# Altium schematic sheet 07_HighSpeed_Peripherals.SchDoc
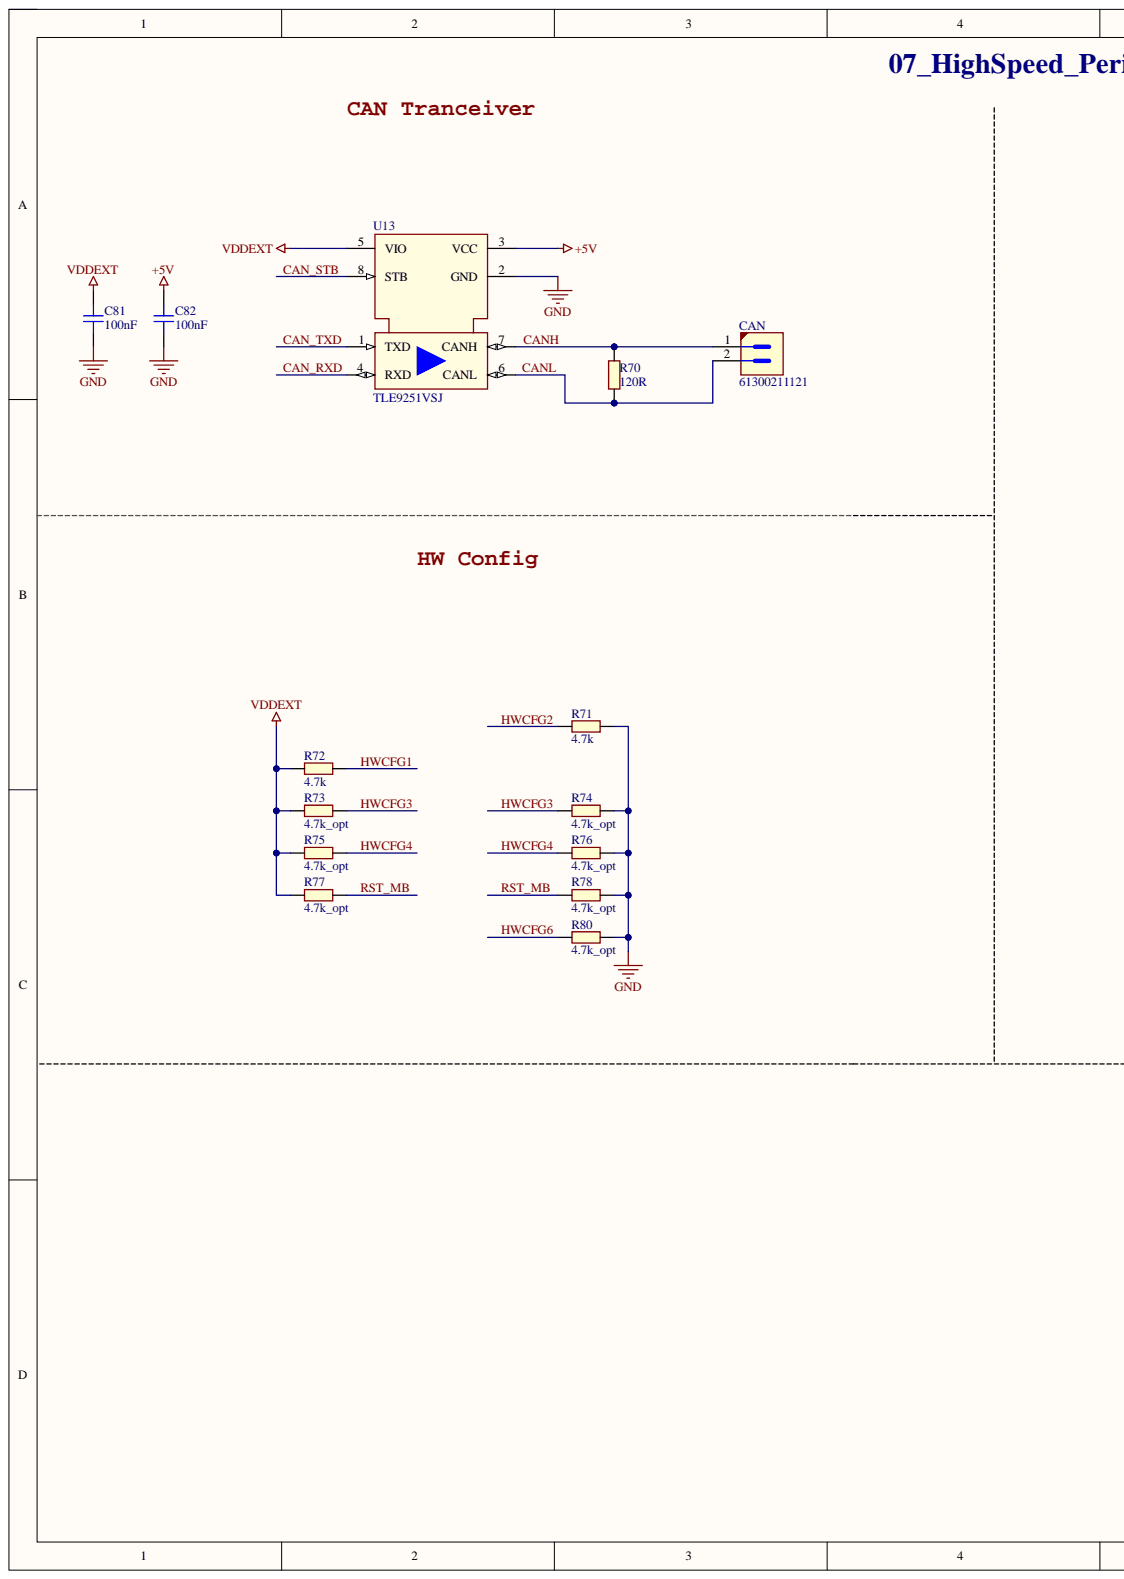
[diagram p0: 07_HighSpeed_Peripherals.SchDoc, left]
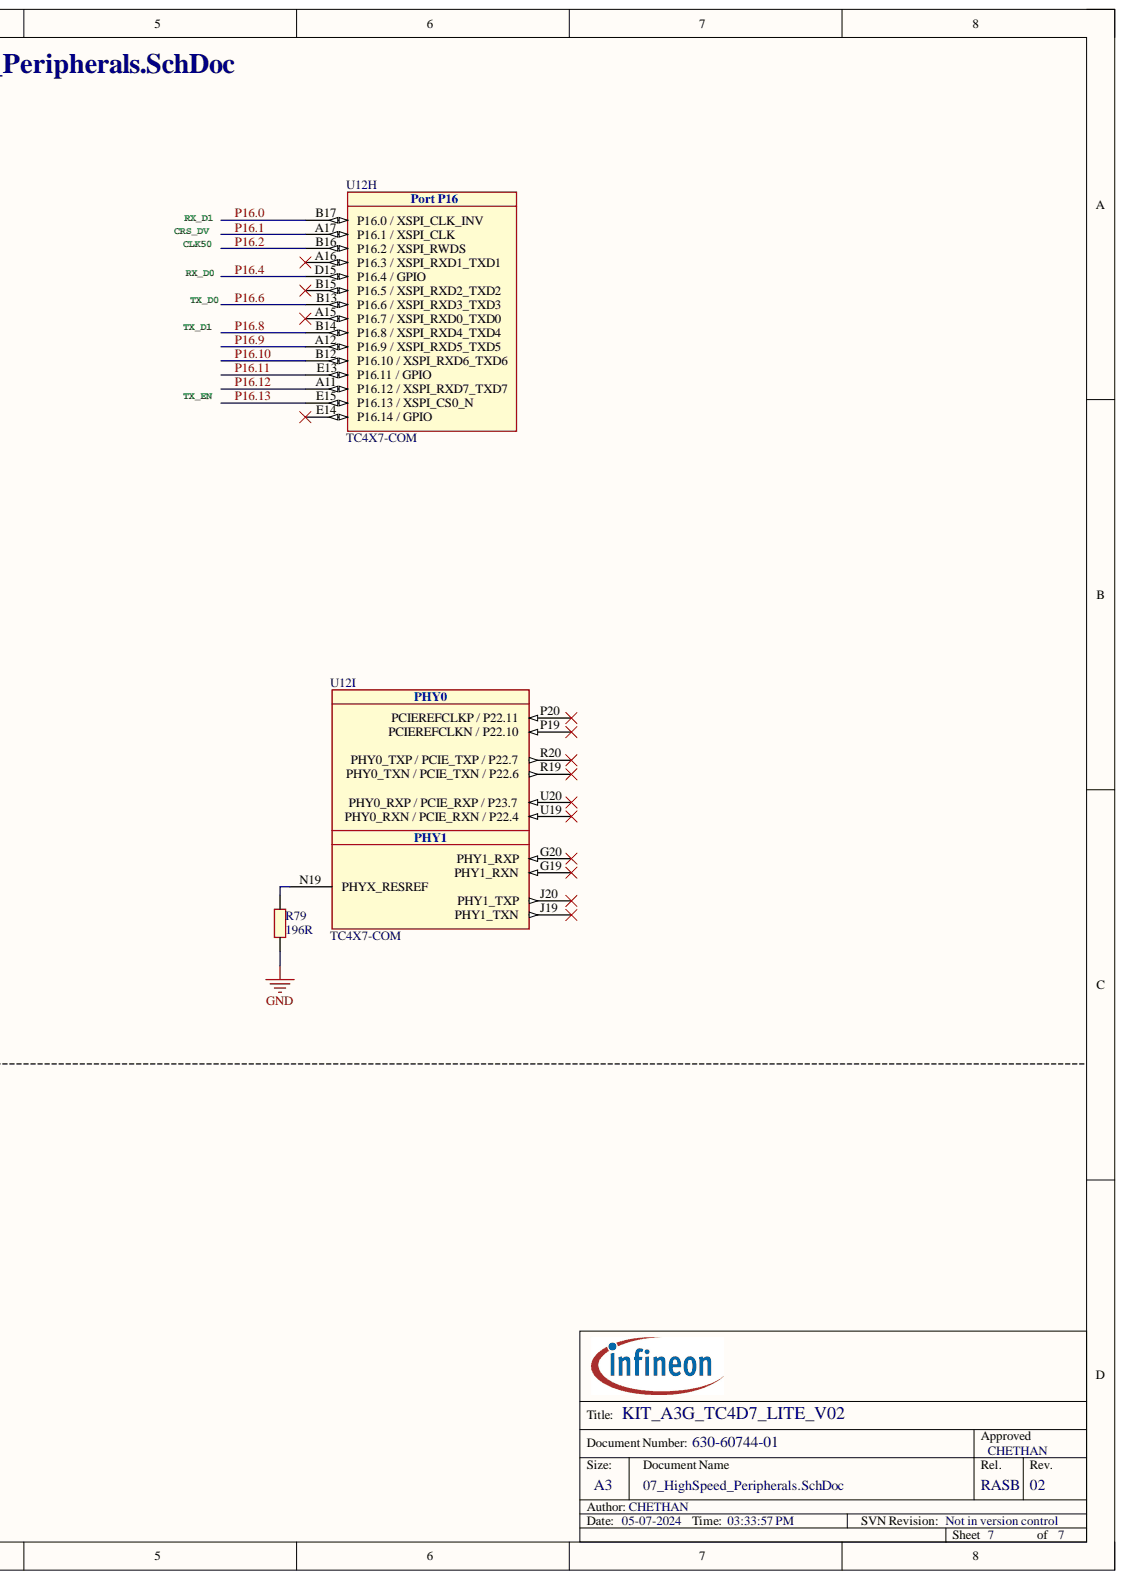
[diagram p1: 07_HighSpeed_Peripherals.SchDoc, right]

## Parts
C81  100nF  [CAPC1005X55N-1]
C82  100nF  [CAPC1005X55N-1]
CAN  61300211121  [HDRV2W64P254_1X2_496X248X838B]
R70  120R  [RESC1005X40N]
R71  4.7k  [RESC1609X50N]
R72  4.7k  [RESC1609X50N]
R73  4.7k_opt  value 4.7k  [RESC1609X50N]
R74  4.7k_opt  [RESC1609X50N]
R75  4.7k_opt  [RESC1609X50N]
R76  4.7k_opt  [RESC1609X50N]
R77  4.7k_opt  [RESC1609X50N]
R78  4.7k_opt  [RESC1609X50N]
R79  196R  [RESC1005X40N]
R80  4.7k_opt  [RESC1609X50N]
U12  TC4X7-COM  [TC4X7_292BGA]  (parts H,I)
U13  TLE9251VSJ  [SOIC127P600X175-8N-3]

## Nets
+5V: C82.2 U13.3(VCC)
GND: C81.1 C82.1 R71.2 R74.2 R76.2 R78.2 R79.2 R80.2 U13.2(GND)
VDDEXT: C81.2 R72.1 R73.1 R75.1 R77.1 U13.5(VIO)
CAN_RXD: U13.4(RXD)
CAN_STB: U13.8(STB)
CAN_TXD: U13.1(TXD)
CANH: CAN.1 R70.2 U13.7(CANH)
CANL: CAN.2 R70.1 U13.6(CANL)
HWCFG1: R72.2
HWCFG2: R71.1
HWCFG3: R73.2 R74.1
HWCFG4: R75.2 R76.1
HWCFG6: R80.1
P16.0: U12.B17(P16.0_/_XSPI_CLK_INV)
P16.1: U12.A17(P16.1_/_XSPI_CLK) U12.B12(P16.10_/_XSPI_RXD6_TXD6)  [also labelled P16.10]
P16.2: U12.B16(P16.2_/_XSPI_RWDS)
P16.4: U12.D15(P16.4_/_GPIO)
P16.6: U12.B13(P16.6_/_XSPI_RXD3_TXD3)
P16.8: U12.B14(P16.8_/_XSPI_RXD4_TXD4)
P16.9: U12.A12(P16.9_/_XSPI_RXD5_TXD5)
P16.11: U12.E13(P16.11_/_GPIO)
P16.12: U12.A11(P16.12_/_XSPI_RXD7_TXD7)
P16.13: U12.E15(P16.13_/_XSPI_CS0_N)
RST_MB: R77.2 R78.1
NetR79_1: R79.1 U12.N19(PHYX_RESREF)

Not connected: U12 A15(P16.7_/_XSPI_RXD0_TXD0) A16(P16.3_/_XSPI_RXD1_TXD1) B15(P16.5_/_XSPI_RXD2_TXD2) E14(P16.14_/_GPIO) G19(PHY1_RXN) G20(PHY1_RXP) J19(PHY1_TXN) J20(PHY1_TXP) P19(PCIEREFCLKN_/_P22.10) P20(PCIEREFCLKP_/_P22.11) R19(PHY0_TXN_/_PCIE_TXN_/_P22.6) R20(PHY0_TXP_/_PCIE_TXP_/_P22.7) U19(PHY0_RXN_/_PCIE_RXN_/_P22.4) U20(PHY0_RXP_/_PCIE_RXP_/_P23.7)

## Library data of the parts
C81 C82 = 308680: 0.1 µF ±10% 16V Ceramic Capacitor X7R 0402 (1005 Metric); Manufacturer: TDK Corporation; Manufacturer Component Status: Active; Manufacturer Order Number: CGA2B1X7R1C104K050BC; Multiple Parts: No; Alternate View: Yes; Automotive Qualified: AEC Q200; Combined Parameters: TC:X7R (EIA);Tol.:-10%/+10%;Vdc:16V; Legacy DeviceID: CAP01010669; Package Imperial: 0402; Package Metric: 1005; QuickPick: 100nF / 16V / 10% / X7R (EIA) / 0402 (1005); Style: Single; Symbol Type: Capacitor; Single; Standard; 2 Pins; Type: Standard; Version Number: V01; Article Number: 308680; Component Kind: Standard; ComponentLink1Description: Vendor WebSite; ComponentLink1URL: http://www.tdk.com; ComponentLink2Description: Datasheet; ComponentLink2URL: http://webnetprod.muc.infineon.com/PCBPortal_Prod/WorkFlows/AltiumComponent/StandardDocumentation?Versionid=308681; Legacy PartNumber: CAP01010669; Package Description: Capacitor,non-polarized,Chip;1.00mm L X 0.50mm W X 0.55mm H; PackageReference: 0402 (1005); Part Type: Capacitor; PLMStatus: Active; ROHS Compliant: Y; Second Source allowed: Yes; Supplier 1: Farnell; Supplier 2: DigiKey; Supplier 3: Mouser; Supplier Part Number 1: 2458205; Supplier Part Number 2: 445-5613-1-ND; Supplier Part Number 3: 810-CGA2B1X7R1C104K; Technology: Ceramic; Temperature max: 125; Temperature min: -55; Temperature Range: Military; Tolerance Neg: 10%; Tolerance Pos: 10%; Value: 100nF; Voltage DC (max): 16V; Library Name: AURIX_Lite_Kit_V2.0.SCHLIB
CAN = 378188: Connector Header Through Hole 2 position 0.100" (2.54mm); Legacy PartNumber: CON01500112; Manufacturer: Samtec; Manufacturer Component Status: Active; Alternat View: Yes; Automotive Qualified: No; Combined Parameters: Board-to-Board Header (male);2x1;Mounting:THT;Orient:0Â° (vertical);Shielded:No; Legacy DeviceID: SAM_HTSW-102-07-L-S; QuickPick: 0Â° (vertical) / Board-to-Board; Style: Strip; Version Number: V01; Voltage DC (max): na; Article Number: 378188; Component Kind: Standard; ComponentLink1Description: Vendor WebSite; ComponentLink1URL: http://www.samtec.com/; ComponentLink2Description: Datasheet; ComponentLink2URL: http://webnetprod.muc.infineon.com/PCBPortal_Prod/WorkFlows/AltiumComponent/StandardDocumentation?Versionid=378189; Manufacturer Order Number: 61300211121; Package Description: Header,vertical,2.54mm pitch;0.64mm lead width,2 pins,1 row,2 pins per row,4.955mm L X 2.48mm W X 8.38mm H body; Part Type: Connector; PLMStatus: Active; ROHS Compliant: Y; Second Source allowed: Yes; Supplier 1: Farnell; Supplier 2: DigiKey; Supplier 3: Mouser; Supplier Part Number 2: 732-5315-ND; Temperature max: 125; Temperature min: -55; Temperature Range: Military; Type: Board-to-Board; Value: 61300211121; Library Name: AURIX_Lite_Kit_V2.0.SCHLIB
R70 = 341067: 120 Ohms ±1% 0.063W, 1/16W Chip Resistor 0402 (1005 Metric) Automotive AEC-Q200 Thick Film; Manufacturer: Vishay; Manufacturer Component Status: Active; Manufacturer Order Number: CRCW0402120RFKED; Multiple Parts: No; Alternate View: Yes; Automotive Qualified: AEC Q200; Combined Parameters: TCR:100ppm/K;Tol.:-1%/+1%;Vdc:50V;Idc:-;P:63mW; Legacy DeviceID: RES01800237; QuickPick: 120R / 1% / 0.0630 / 0402; Style: Single; Symbol Type: Resistor; Single; Standard; 2 Pins; Version Number: V01; Voltage DC (max): 50V; Article Number: 341067; Component Kind: Standard; ComponentLink1Description: Vendor WebSite; ComponentLink1URL: http://www.vishay.com; ComponentLink2Description: Datasheet; ComponentLink2URL: http://webnetprod.muc.infineon.com/PCBPortal_Prod/WorkFlows/AltiumComponent/StandardDocumentation?Versionid=341068; Legacy PartNumber: RES01800237; PackageReference: 0402; Part Type: Resistor; PLMStatus: Active; ROHS Compliant: Y; Second Source allowed: Yes; Supplier 1: Farnell; Supplier 2: DigiKey; Supplier 3: Mouser; Supplier Part Number 2: 541-120LCT-ND; Technology: Thick Film; Temperature max: 155; Temperature min: -55; Temperature Range: Military; Tolerance Neg: 1%; Tolerance Pos: 1%; Type: Standard; Value: 120R; Library Name: AURIX_Lite_Kit_V2.0.SCHLIB
R71-R73 = 345982: 4.7 kOhms ±1% 0.1W, 1/10W Chip Resistor 0603 (1608 Metric) Automotive AEC-Q200 Thick Film; Manufacturer: Vishay; Manufacturer Order Number: CRCW06034K70FKEA; Multiple Parts: No; Alternate View: Yes; Automotive Qualified: AEC Q200; Combined Parameters: TCR:100ppm/K;Tol.:-1%/+1%;Vdc:75V;Idc:-;P:100mW; Legacy DeviceID: RES01801219; PLMStatus: Active; QuickPick: 4.7k / 1% / 0.10 / 0603; Style: Single; Symbol Type: Resistor; Single; Standard; 2 Pins; Version Number: V01; Voltage DC (max): 75V; Article Number: 345982; Component Kind: Standard; ComponentLink1Description: Vendor WebSite; ComponentLink1URL: http://www.vishay.com; ComponentLink2Description: Datasheet; ComponentLink2URL: http://webnetprod.muc.infineon.com/PCBPortal_Prod/WorkFlows/AltiumComponent/StandardDocumentation?Versionid=345983; Legacy PartNumber: RES01801219; PackageReference: 0603; Part Type: Resistor; ROHS Compliant: Y; Second Source allowed: Yes; Supplier 1: Farnell; Supplier 2: DigiKey; Supplier 3: Mouser; Supplier Part Number 2: 541-4.70KHCT-ND; Technology: Thick Film; Temperature max: 155; Temperature min: -55; Temperature Range: Military; Tolerance Neg: 1%; Tolerance Pos: 1%; Type: Standard; Library Name: AURIX_Lite_Kit_V2.0.SCHLIB
  R71 R72: Value: 4.7k
R74-R78 R80 = 345982: 4.7 kOhms ±1% 0.1W, 1/10W Chip Resistor 0603 (1608 Metric) Automotive AEC-Q200 Thick Film; Manufacturer: Vishay; Manufacturer Order Number: CRCW06034K70FKEA; Multiple Parts: No; Alternate View: Yes; Automotive Qualified: AEC Q200; Combined Parameters: TCR:100ppm/K;Tol.:-1%/+1%;Vdc:75V;Idc:-;P:100mW; Legacy DeviceID: RES01801219; PLMStatus: Active; QuickPick: 4.7k / 1% / 0.10 / 0603; Style: Single; Symbol Type: Resistor; Single; Standard; 2 Pins; Value: 4.7k; Version Number: V01; Voltage DC (max): 75V; Article Number: 345982; Component Kind: Standard; ComponentLink1Description: Vendor WebSite; ComponentLink1URL: http://www.vishay.com; ComponentLink2Description: Datasheet; ComponentLink2URL: http://webnetprod.muc.infineon.com/PCBPortal_Prod/WorkFlows/AltiumComponent/StandardDocumentation?Versionid=345983; Legacy PartNumber: RES01801219; PackageReference: 0603; Part Type: Resistor; ROHS Compliant: Y; Second Source allowed: Yes; Supplier 1: Farnell; Supplier 2: DigiKey; Supplier 3: Mouser; Supplier Part Number 2: 541-4.70KHCT-ND; Technology: Thick Film; Temperature max: 155; Temperature min: -55; Temperature Range: Military; Tolerance Neg: 1%; Tolerance Pos: 1%; Type: Standard; Library Name: AURIX_Lite_Kit_V2.0.SCHLIB
R79 = 341182: 196 Ohms ±1% 0.063W, 1/16W Chip Resistor 0402 (1005 Metric) Automotive AEC-Q200 Thick Film; Manufacturer: Vishay; Manufacturer Order Number: CRCW0402196RFKED; Multiple Parts: No; Alternate View: Yes; Automotive Qualified: AEC Q200; Combined Parameters: TCR:100ppm/K;Tol.:-1%/+1%;Vdc:50V;Idc:-;P:63mW; Database Table Name: TPartsAll; Legacy DeviceID: RES01800260; PLMStatus: Active; QuickPick: 196R / 1% / 0.063 / 0402; Style: Single; Symbol Type: Resistor; Single; Standard; 2 Pins; Version Number: V01; Voltage DC (max): 50V; Article Number: 341182; Component Kind: Standard; ComponentLink1Description: Vendor WebSite; ComponentLink1URL: http://www.vishay.com; ComponentLink2Description: Datasheet; ComponentLink2URL: http://webnetprod.muc.infineon.com/PCBPortal_Prod/WorkFlows/AltiumComponent/StandardDocumentation?Versionid=341183; Legacy PartNumber: RES01800260; Library Name: PCBLibraryData.SVNDbLib; PackageReference: 0402; Part Type: Resistor; ROHS Compliant: Y; Second Source allowed: Yes; Supplier 1: Farnell; Supplier 2: DigiKey; Supplier 3: Mouser; Supplier Part Number 2: 541-196LCT-ND; Technology: Thick Film; Temperature max: 155; Temperature min: -55; Temperature Range: Military; Tolerance Neg: 1%; Tolerance Pos: 1%; Type: Standard; Value: 196R
U12 = 606443_BGA292: TC4xx_BGA292_COM; Manufacturer: Infineon Technologies; Multiple Parts: Yes; Alternate View: No; Combined Parameters: App.:-;-;Vdc min/max:-;Fmax:-;RAM:-;ROM:-;Flash:-; ComponentStatus: Created; Legacy DeviceID: IFX_TC4xx_BGA292_COM; Pins Electrical: 292; PLM Status: Preliminary; PLMStatus: Active; QuickPick: BGA292; Value: IFX_TC4xx_BGA292_COM; Version Number: V01; Voltage DC (max): na; Article Number: 606443_BGA292; Component Kind: Standard; ComponentLink1Description: Vendor WebSite; ComponentLink1URL: https://www.infineon.com/; ComponentLink2Description: Datasheet; Description (long): TC4xx_BGA292_COM; Footprint Ref: FCBGA292_4L; Library Name: TriBoard_TC4X7_COM_V20.SCHLIB; Library Ref: TC4xx_BGA292_COM; Manufacturer Order Number: TC4xx_BGA292_COM; Package Description: BGA, SMD; 0.80 mm Pitch, 292 pin, 17.00 mm L X 17.00 mm W X 1.10 mm H body; Package Reference: BGA292; PackageReference: BGA292; Part Type: Microcontroller; Pins Total: 292; Product Name: IFX_TC4xx_BGA292_COM; Second Source allowed: Yes; Supplier 1: Farnell; Supplier 2: DigiKey; Supplier 3: Mouser; Symbol Type: TC4xx_BGA292_COM; Temperature Range: Military; Vendor WebSite: https://www.infineon.com/
U13 = 426006: 1/1 Transceiver CANbus PG-DSO-8; Legacy PartNumber: IFX01400833; Manufacturer: Infineon Technologies; Automotive Qualified: Yes; Combined Parameters: - -;Vdc min/max:-;Iout:-;Fmax:-; Legacy DeviceID: IFX_TLE9251VSJ; PLMStatus: Active; QuickPick: PG-DSO-8-44; Symbol Type: High Speed CAN Transceiver; Version Number: V01; Voltage DC (max): na; Article Number: 426006; Component Kind: Standard; ComponentLink1Description: Vendor WebSite; ComponentLink1URL: https://www.infineon.com/; ComponentLink2Description: Datasheet; ComponentLink2URL: http://webnetprod.muc.infineon.com/PCBPortal_Prod/WorkFlows/AltiumComponent/StandardDocumentation?Versionid=426007; Manufacturer Order Number: TLE9251VSJ; Package Description: SOIC,1.27mm pitch;8 pin,3.90mm W X 4.90mm L X 1.75mm H body; PackageReference: PG-DSO-8-44; Part Type: Integrated Circuit; Second Source allowed: Yes; Supplier 1: Farnell; Supplier 2: DigiKey; Supplier 3: Mouser; Supplier Part Number 2: TLE9251VSJXUMA1CT-ND; Temperature Range: Military; Value: TLE9251VSJ; Library Name: AURIX_4x7_Lite_Kit.SCHLIB
